# Altium schematic sheet [06] - GPIO.SchDoc("[06] - GPIO")
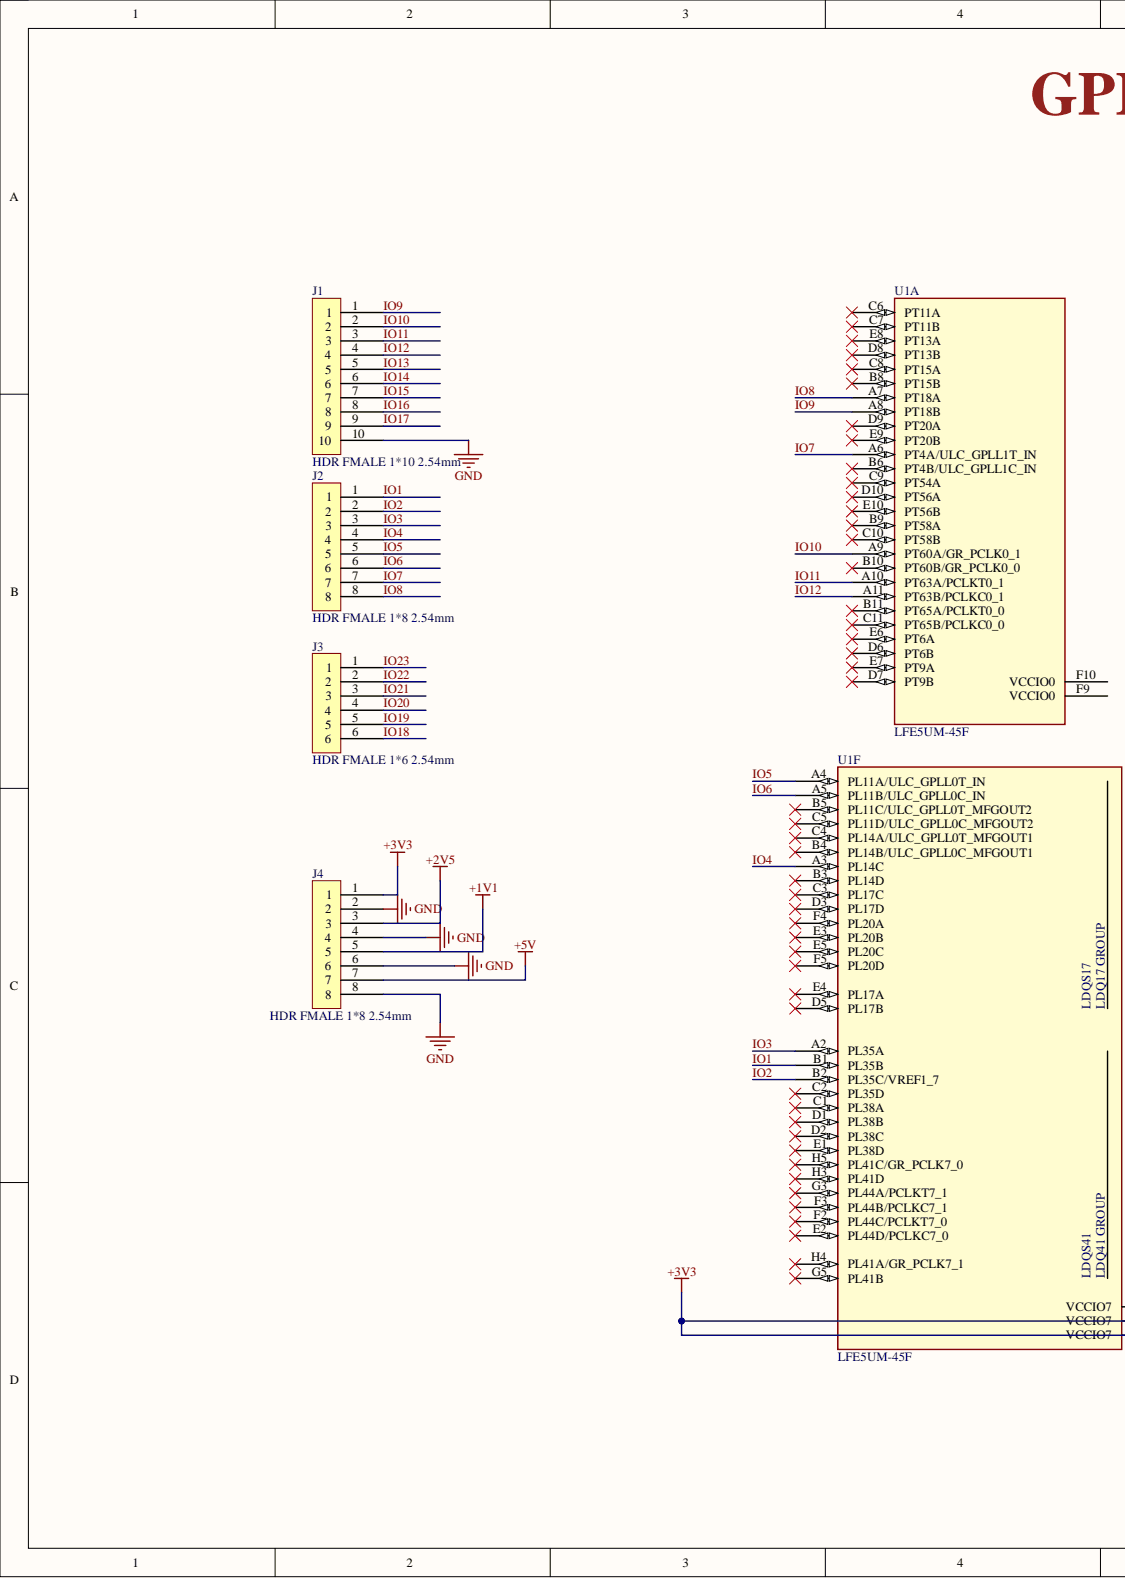
[diagram p0: [06] - GPIO.SchDoc, left]
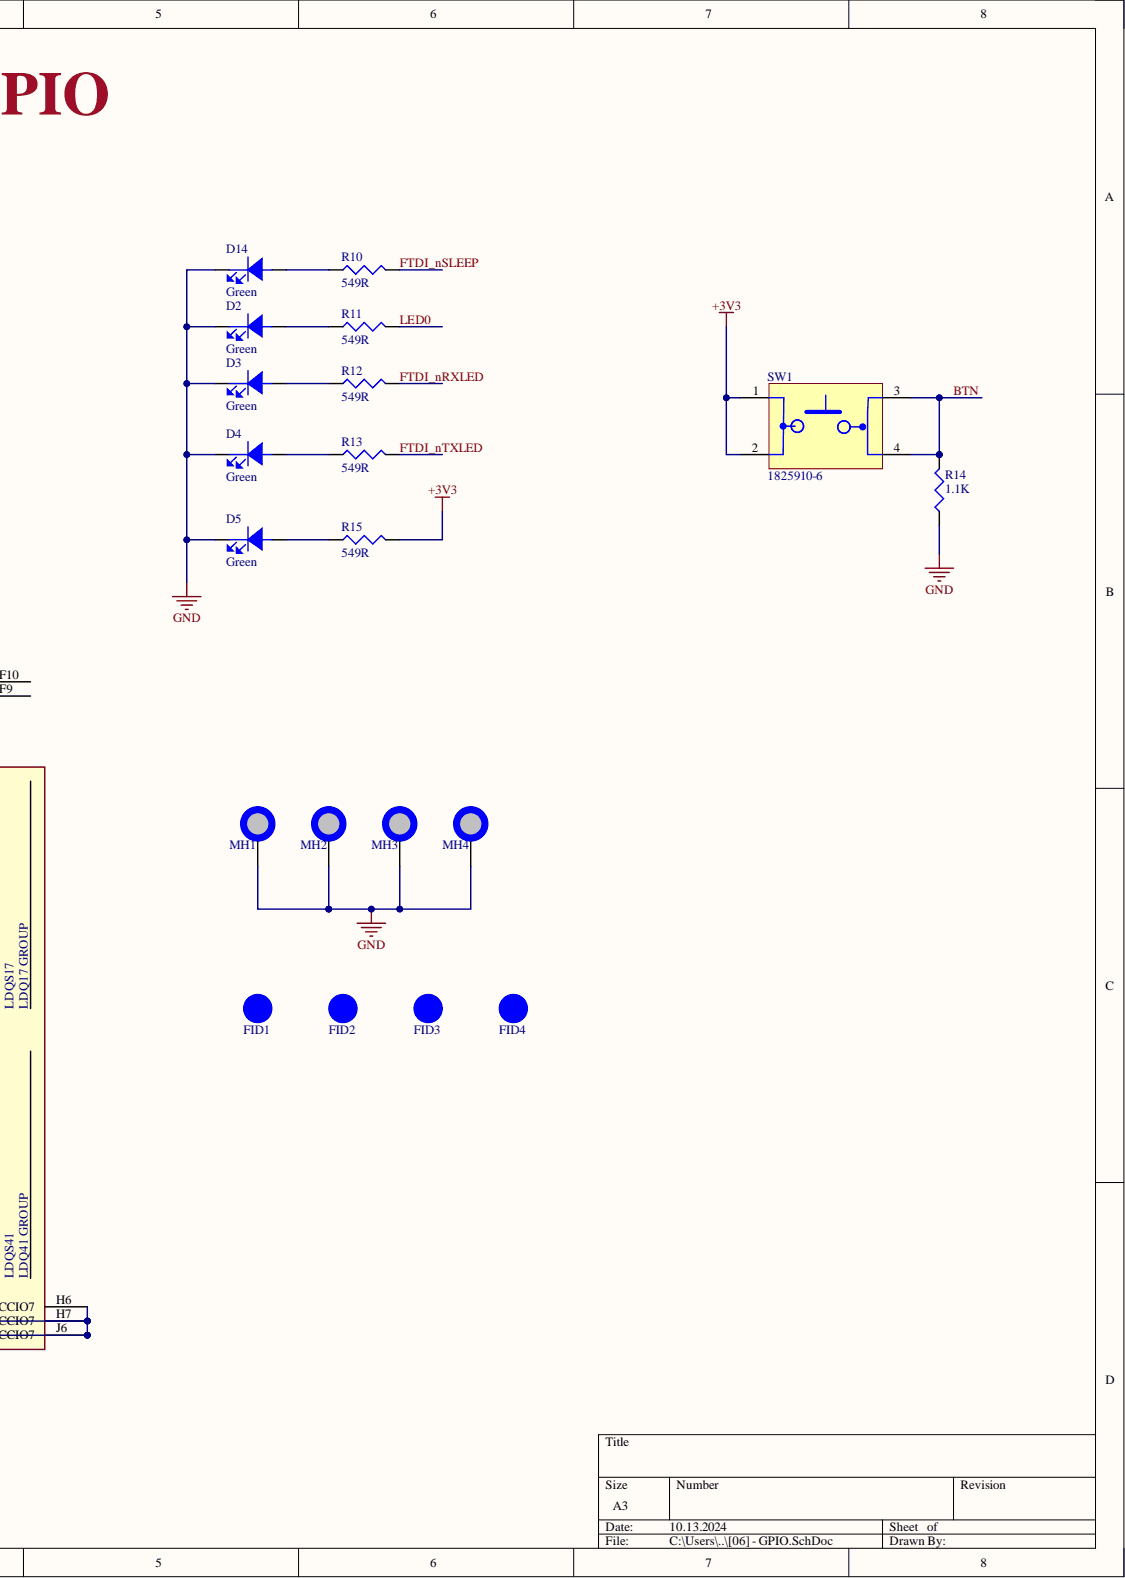
[diagram p1: [06] - GPIO.SchDoc, right]

## Parts
D2  Green  [LED_0603]
D3  Green  [LED_0603]
D4  Green  [LED_0603]
D5  Green  [LED_0603]
D14  Green  [LED_0603]
FID1  FID_1MMC  [FID_40x120D]  (value not drawn)  (no BOM)
FID2  FID_1MMC  [FID_40x120D]  (value not drawn)  (no BOM)
FID3  FID_1MMC  [FID_40x120D]  (value not drawn)  (no BOM)
FID4  FID_1MMC  [FID_40x120D]  (value not drawn)  (no BOM)
J1  HDR FMALE 1*10 2.54mm  Lead Pitch: 2.54mm  [Hdr_Female_1*10_2.54mm]
J2  HDR FMALE 1*8 2.54mm  Pitch: 2.54mm  [Hdr_Female_1*8_2.54mm]
J3  HDR FMALE 1*6 2.54mm  Pitch: 2.54mm  [Hdr_Female_1*6_2.54mm]
J4  HDR FMALE 1*8 2.54mm  Pitch: 2.54mm  [Hdr_Female_1*8_2.54mm]
MH1  MH_7.4MMC_3.2MMD  [MH_7.4MMC_3.2MMD]  (value not drawn)  (no BOM)
MH2  MH_7.4MMC_3.2MMD  [MH_7.4MMC_3.2MMD]  (value not drawn)  (no BOM)
MH3  MH_7.4MMC_3.2MMD  [MH_7.4MMC_3.2MMD]  (value not drawn)  (no BOM)
MH4  MH_7.4MMC_3.2MMD  [MH_7.4MMC_3.2MMD]  (value not drawn)  (no BOM)
R10  549R  [R0603]
R11  549R  [R0603]
R12  549R  [R0603]
R13  549R  [R0603]
R14  1.1K  [R0603]
R15  549R  [R0603]
SW1  1825910-6  [1825910-6]
U1  LFE5UM-45F  [LFE5BG381]  (parts A,F)

## Nets
+1V1: J4.5
+2V5: J4.3
+3V3: J4.1 R15.2 SW1.1 SW1.2 U1.H6(VCCIO7) U1.H7(VCCIO7) U1.J6(VCCIO7)
+5V: J4.7
GND: D2.1 D3.1 D4.1 D5.1 D14.1 J1.10 J4.2 J4.4 J4.6 J4.8 MH1.1 MH2.1 MH3.1 MH4.1 R14.1
BTN: R14.2 SW1.3 SW1.4
FTDI_nRXLED: R12.2
FTDI_nSLEEP: R10.2
FTDI_nTXLED: R13.2
IO1: J2.1 U1.B1(PL35B)
IO2: J2.2 U1.B2(PL35C/VREF1_7)
IO3: J2.3 U1.A2(PL35A)
IO4: J2.4 U1.A3(PL14C)
IO5: J2.5 U1.A4(PL11A/ULC_GPLL0T_IN)
IO6: J2.6 U1.A5(PL11B/ULC_GPLL0C_IN)
IO7: J2.7 U1.A6(PT4A/ULC_GPLL1T_IN)
IO8: J2.8 U1.A7(PT18A)
IO9: J1.1 U1.A8(PT18B)
IO10: J1.2 U1.A9(PT60A/GR_PCLK0_1)
IO11: J1.3 U1.A10(PT63A/PCLKT0_1)
IO12: J1.4 U1.A11(PT63B/PCLKC0_1)
IO13: J1.5
IO14: J1.6
IO15: J1.7
IO16: J1.8
IO17: J1.9
IO18: J3.6
IO19: J3.5
IO20: J3.4
IO21: J3.3
IO22: J3.2
IO23: J3.1
LED0: R11.2
NetD2_2: D2.2 R11.1
NetD3_2: D3.2 R12.1
NetD4_2: D4.2 R13.1
NetD5_2: D5.2 R15.1
NetD14_2: D14.2 R10.1

Not connected: U1 B3(PL14D) B4(PL14B/ULC_GPLL0C_MFGOUT1) B5(PL11C/ULC_GPLL0T_MFGOUT2) B6(PT4B/ULC_GPLL1C_IN) B8(PT15B) B9(PT58A) B10(PT60B/GR_PCLK0_0) B11(PT65A/PCLKT0_0) C1(PL38A) C2(PL35D) C3(PL17C) C4(PL14A/ULC_GPLL0T_MFGOUT1) C5(PL11D/ULC_GPLL0C_MFGOUT2) C6(PT11A) C7(PT11B) C8(PT15A) C9(PT54A) C10(PT58B) C11(PT65B/PCLKC0_0) D1(PL38B) D2(PL38C) D3(PL17D) D5(PL17B) D6(PT6B) D7(PT9B) D8(PT13B) D9(PT20A) D10(PT56A) E1(PL38D) E2(PL44D/PCLKC7_0) E3(PL20B) E4(PL17A) E5(PL20C) E6(PT6A) E7(PT9A) E8(PT13A) E9(PT20B) E10(PT56B) F2(PL44C/PCLKT7_0) F3(PL44B/PCLKC7_1) F4(PL20A) F5(PL20D) F9(VCCIO0) F10(VCCIO0) G3(PL44A/PCLKT7_1) G5(PL41B) H3(PL41D) H4(PL41A/GR_PCLK7_1) H5(PL41C/GR_PCLK7_0)

## Library data of the parts
D2-D5 D14 = LGL29K-G2J1-24-Z: LED Uni-Color Green 572nm 2-Pin Chip 0603(1608Metric) T/R; Manufacturer: Osram Opto; Dominant Wavelength: 570nm; Forward Current: 20mA; Forward Voltage: 2.2V; Lens Color: White; Lens Size: 0.8mm; Lens Style: Flat,Diffused,Colorless,Rectangular; Lens Transparency: Diffused; Luminous Flux: 0.012lm; Luminous Intensity: 5.6mcd; Number of Elements: 1; Reverse Voltage: 12V; Test Current: 2mA; Viewing Angle: 160°; Case Code (Imperial): 0603; Case Code (Metric): 1608; Case/Package: 0603; Color: Green; Component Kind: Standard; ComponentLink1Description: http://www.mouser.com/ds/2/311/LG%20L29K%20-%20SMARTLED%200603-335234.pdf?src-supplier=Mouser; ComponentLink1URL: http://www.mouser.com/ds/2/311/LG%20L29K%20-%20SMARTLED%200603-335234.pdf?src-supplier=Mouser; ComponentLink2Description: https://dammedia.osram.info/media/resource/hires/osram-dam-2493945/LG%20L29K.pdf?src-supplier=Digi-Key; ComponentLink2URL: https://dammedia.osram.info/media/resource/hires/osram-dam-2493945/LG%20L29K.pdf?src-supplier=Digi-Key; ComponentLink3Description: https://www.verical.com/server-webapp/html/getDatasheet?partID=571127&src-supplier=Verical; ComponentLink3URL: https://www.verical.com/server-webapp/html/getDatasheet?partID=571127&src-supplier=Verical; Depth: 0.8mm; Height: 0.65mm; Illumination Color: Green; Length: 1.3mm; Library Name: ECP5_V2.SchLib; Manufacturer Part Number: LGL29K-G2J1-24-Z; Max Operating Temperature: 100°C; Min Operating Temperature: -40°C; Mount: Surface Mount; Number of LEDs: 1; Number of Pins: 2; Package Quantity: 5000; Packaging: Tape and Reel; Pricing 1: 1=0.5, 10=0.363, 100=0.2373, 500=0.17054, 1000=0.13347, 2500=0.12235 (USD); Radiation Hardening: No; Stock 1: 159662; Supplier 1: Digi-Key; Supplier Part Number 1: 475-2709-6-ND; Width: 0.8mm
FID1-FID4 = FID_1MMC: Fiducial 40mil Diameter 120mil Mask; Library Name: ECP5_V2.SchLib
J1 = M20-7821046: 10-WAY SIL VERT SOCKET L/FREE; Manufacturer: Harwin; Connector Type: Receptacle,Socket; Contact Resistance: 20mR; Current Rating: 3A; Gender: Receptacle; Hole Diameter: 0.8mm; Insulation Resistance: 1MR; Mating Cycles: 50; Number of Contacts: 10; Case/Package: SIL; Color: Black; Component Kind: Standard; ComponentLink1Description: https://www.verical.com/server-webapp/html/getDatasheet?partID=559226&src-supplier=Verical; ComponentLink1URL: https://www.verical.com/server-webapp/html/getDatasheet?partID=559226&src-supplier=Verical; ComponentLink2Description: https://cdn.harwin.com/pdfs/M20-782.pdf?src-supplier=Digi-Key; ComponentLink2URL: https://cdn.harwin.com/pdfs/M20-782.pdf?src-supplier=Digi-Key; ComponentLink3Description: http://www.harwin.com/include/downloads/drawings/M20-782.PDF?src-supplier=Avnet; ComponentLink3URL: http://www.harwin.com/include/downloads/drawings/M20-782.PDF?src-supplier=Avnet; ComponentLink4Description: https://cdn.harwin.com/pdfs/Harwin_PC_Connectors_M20.pdf?src-supplier=Avnet+Europe; ComponentLink4URL: https://cdn.harwin.com/pdfs/Harwin_PC_Connectors_M20.pdf?src-supplier=Avnet+Europe; ComponentLink5Description: http://uk.rs-online.com/webdocs/1422/0900766b81422659.pdf?src-supplier=RSComponents; ComponentLink5URL: http://uk.rs-online.com/webdocs/1422/0900766b81422659.pdf?src-supplier=RSComponents; Contact Gender: Female; Contact Material: Bronze; Contact Plating: Tin; Depth: 2.5mm; Housing Color: Black; Housing Material: Polyamide; Length: 25.8mm; Library Name: ECP5_V2.SchLib; Manufacturer Part Number: M20-7821046; Max Current Rating: 3A; Max Operating Temperature: 105°C; Min Operating Temperature: -40°C; Mount: Through Hole; Number of Positions: 10; Number of Rows: 1; Orientation: Straight; Package Quantity: 23; Packaging: Rail/Tube; Pitch: 2.54mm; Pricing 1: 1=1.28, 22=1.12182, 110=0.968, 506=0.792, 1012=0.704, 5016=0.616, 10010=0.5852 (USD); Radiation Hardening: No; REACH SVHC: No SVHC; Stock 1: 415; Supplier 1: Digi-Key; Supplier Part Number 1: 952-1847-ND; Termination: Solder
J2 J4 = M20-7820842: 08-WAY SIL VERT SOCKET L/FREE; Manufacturer: Harwin; Connector Type: Receptacle,Socket; Contact Resistance: 20mR; Gender: Female; Max Current Rating: 3A; Number of Contacts: 8; Case/Package: SIL; Color: Black; Component Kind: Standard; ComponentLink1Description: https://cdn.harwin.com/pdfs/Harwin_PC_Connectors_M20.pdf?src-supplier=Avnet+Europe; ComponentLink1URL: https://cdn.harwin.com/pdfs/Harwin_PC_Connectors_M20.pdf?src-supplier=Avnet+Europe; ComponentLink2Description: http://www.harwin.com/include/downloads/drawings/M20-782.PDF?src-supplier=Avnet; ComponentLink2URL: http://www.harwin.com/include/downloads/drawings/M20-782.PDF?src-supplier=Avnet; Contact Gender: Female; Contact Material: Bronze; Contact Plating: Tin,Gold; Depth: 2.5mm; Housing Color: Black; Housing Material: Polyamide; Length: 20.72mm; Library Name: ECP5_V2.SchLib; Manufacturer Part Number: M20-7820842; Max Operating Temperature: 105°C; Min Operating Temperature: -40°C; Mount: Through Hole; Number of Positions: 8; Number of Rows: 1; Orientation: Straight; Package Quantity: 29; Packaging: Rail/Tube; Pricing 1: 1=1.1, 27=0.91444, 108=0.83824, 513=0.68581, 1026=0.6096, 5022=0.5334, 10017=0.50673 (USD); Radiation Hardening: No; Stock 1: 4198; Supplier 1: Digi-Key; Supplier Part Number 1: 952-1823-ND; Termination: Solder; Weight: 0.025397oz
J3 = M20-7820646: 06-WAY SIL VERT SOCKET L/FREE; Manufacturer: Harwin; Connector Type: Receptacle,Socket; Contact Resistance: 20mR; Gender: Female; Max Current Rating: 3A; Number of Contacts: 6; Case/Package: SIL; Color: Black; Component Kind: Standard; Contact Gender: Female; Contact Material: Bronze; Contact Plating: Tin; Depth: 2.5mm; Housing Color: Black; Housing Material: Nylon; Length: 15.64mm; Library Name: ECP5_V2.SchLib; Manufacturer Part Number: M20-7820646; Max Operating Temperature: 105°C; Min Operating Temperature: -40°C; Mount: Through Hole; Number of Positions: 6; Number of Rows: 1; Orientation: Straight; Package Quantity: 38; Packaging: Rail/Tube; Pricing 1: 1=0.96, 36=0.79194, 108=0.72602, 504=0.59401, 1008=0.528, 5004=0.462, 10008=0.4389 (USD); Radiation Hardening: No; Stock 1: 2187; Supplier 1: Digi-Key; Supplier Part Number 1: 952-1809-ND; Termination: Solder
MH1-MH4 = MH_7.4MMC_3.2MMD: Mounting Hole 7..4mm Diameter_3.2mm Drill; Library Name: ECP5_V2.SchLib
R10-R13 R15 = RC0603FR-07549RL: RES SMD 549 OHM 1% 1/10W 0603; Manufacturer: Yageo; Max Power Dissipation: 100mW; Temperature Coefficient: 100ppm/°C; Voltage Rating: 50V; Case Code (Imperial): 0603; Case Code (Metric): 1608; Case/Package: 0603; Component Kind: Standard; ComponentLink1Description: http://www.yageo.com/documents/recent/PYu-RC_Group_51_RoHS_L_10.pdf?src-supplier=Digi-Key; ComponentLink1URL: http://www.yageo.com/documents/recent/PYu-RC_Group_51_RoHS_L_10.pdf?src-supplier=Digi-Key; ComponentLink2Description: http://www.yageo.com/documents/recent/PYu-RC_Group_51_RoHS_L_9.pdf?src-supplier=Digi-Key; ComponentLink2URL: http://www.yageo.com/documents/recent/PYu-RC_Group_51_RoHS_L_9.pdf?src-supplier=Digi-Key; Composition: Thick Film; Depth: 0.8mm; Features: Moisture Resistant; Height: 0.022inch; Lead Free: Lead Free; Length: 1.6mm; Library Name: ECP5_V2.SchLib; Manufacturer Part Number: RC0603FR-07549RL; Max Operating Temperature: 155°C; Military Standard: Not; Min Operating Temperature: -55°C; Number of Terminations: 2; Package Quantity: 1; Packaging: Tape and Reel; Power Rating: 100mW; Pricing 1: 1=0.1, 10=0.023, 100=0.0094, 1000=0.00421, 2500=0.00366 (USD); Resistance: 549R; Stock 1: 134588; Supplier 1: Digi-Key; Supplier Part Number 1: 311-549HRDKR-ND; Termination: SMD/SMT; Tolerance: 1%; Voltage Rating (DC): 50V; Width: 0.031inch
R14 = RC0603FR-071K1L: RES SMD 1.1K OHM 1% 1/10W 0603; Manufacturer: Yageo; Max Power Dissipation: 100mW; Resistance: 1.1kR; Temperature Coefficient: 100ppm/°C; Voltage Rating: 50V; Case Code (Imperial): 0603; Case Code (Metric): 1608; Case/Package: 0603; Component Kind: Standard; ComponentLink1Description: http://www.yageo.com/documents/recent/PYu-RC_Group_51_RoHS_L_10.pdf?src-supplier=Digi-Key; ComponentLink1URL: http://www.yageo.com/documents/recent/PYu-RC_Group_51_RoHS_L_10.pdf?src-supplier=Digi-Key; ComponentLink2Description: http://www.yageo.com/documents/recent/PYu-RC_Group_51_RoHS_L_9.pdf?src-supplier=Digi-Key; ComponentLink2URL: http://www.yageo.com/documents/recent/PYu-RC_Group_51_RoHS_L_9.pdf?src-supplier=Digi-Key; Composition: Thick Film; Depth: 0.8mm; Features: Moisture Resistant; Height: 0.022inch; Lead Free: Lead Free; Length: 1.6mm; Library Name: ECP5_V2.SchLib; Manufacturer Part Number: RC0603FR-071K1L; Max Operating Temperature: 155°C; Military Standard: Not; Min Operating Temperature: -55°C; Number of Pins: 2; Number of Terminations: 2; Package Quantity: 1; Packaging: Tape and Reel; Power Rating: 100mW; Pricing 1: 1=0.1, 10=0.023, 100=0.0094, 1000=0.00421, 2500=0.00366 (USD); REACH SVHC: No SVHC; Stock 1: 534181; Supplier 1: Digi-Key; Supplier Part Number 1: 311-1.10KHRDKR-ND; Termination: SMD/SMT; Tolerance: 1%; Voltage Rating (DC): 50V; Width: 0.031inch
SW1 = 1825910-6: ALCOSWITCH - TE CONNECTIVITY - 1825910-6 - TACT SWITCH, SPST, 0.05A, 24VDC, SOLDER; Manufacturer: TE Connectivity Alcoswitch; Actuator Length: 0.055inch; Actuator Material: PBT; Actuator Type: Standard; Contact Current Rating: 50mA; Contact Resistance: 100mR; Electrical Life: 100000Cycles; Illumination Type: Non-Illuminated,Illuminated; Max Voltage Rating (DC): 24V; Operating Force: 160gf; Switch Function: Off-Mom; Switch Travel: 0.25mm; Component Kind: Standard; ComponentLink1Description: http://www.onlinecomponents.com/productFiles/mf-AMP/1825910-6rqpn1825910-6.pdf?src-supplier=Onlinecomponents.com; ComponentLink1URL: http://www.onlinecomponents.com/productFiles/mf-AMP/1825910-6rqpn1825910-6.pdf?src-supplier=Onlinecomponents.com; ComponentLink2Description: http://www.te.com/commerce/DocumentDelivery/DDEController?Action=srchrtrv&DocNm=1825910&DocType=Customer+Drawing&DocLang=English&src-supplier=Verical; ComponentLink2URL: http://www.te.com/commerce/DocumentDelivery/DDEController?Action=srchrtrv&DocNm=1825910&DocType=Customer+Drawing&DocLang=English&src-supplier=Verical; Contact Material: Copper Alloy; Contact Plating: Silver; ELV: Compliant; Lead Free: Lead Free; Length: 5.99mm; Library Name: ECP5_V2.SchLib; Manufacturer Part Number: 1825910-6; Max Current Rating: 50mA; Max Operating Temperature: 85°C; Mechanical Life: 100000Cycles; Min Operating Temperature: -35°C; Mount: Through Hole; Orientation: Straight; Package Quantity: 750; Packaging: Loose Piece; Pricing 1: 1=0.1, 10=0.101, 25=0.0976, 50=0.094, 100=0.0888, 250=0.08352, 500=0.08006, 1000=0.06091, 5000=0.05047 (USD); REACH SVHC: Unknown; Stock 1: 40831; Supplier 1: Digi-Key; Supplier Part Number 1: 450-1650-ND; Termination: PC Pins; Throw Configuration: SPST; Type: Switch
U1 = LFE5UM-45F-6BG381C: ECP5 Series 44 K LUTs 203 I/O 1.1 V Slow Surface Mount FPGA - CABGA-381; Manufacturer: Lattice Semiconductor; Number of I/Os: 203; Number of Logic Blocks (LABs): 11000; Number of Logic Elements/Cells: 44000; RAM Size: 1990656b; Case/Package: FBGA; Component Kind: Standard; ComponentLink1Description: http://www.latticesemi.com/~/media/LatticeSemi/Documents/DataSheets/ECP5/DS1044.pdf?src-supplier=Digi-Key; ComponentLink1URL: http://www.latticesemi.com/~/media/LatticeSemi/Documents/DataSheets/ECP5/DS1044.pdf?src-supplier=Digi-Key; Lead Free: Lead Free; Library Name: ECP5_V2.SchLib; Manufacturer Part Number: LFE5UM-45F-6BG381C; Max Operating Temperature: 85°C; Min Operating Temperature: 0°C; Mount: Surface Mount; Pricing 1: 90=19.13967 (USD); Stock 1: 0; Supplier 1: Digi-Key; Supplier Part Number 1: 220-1994-ND
